# Altium schematic sheet DRL.SchDoc(DRL)
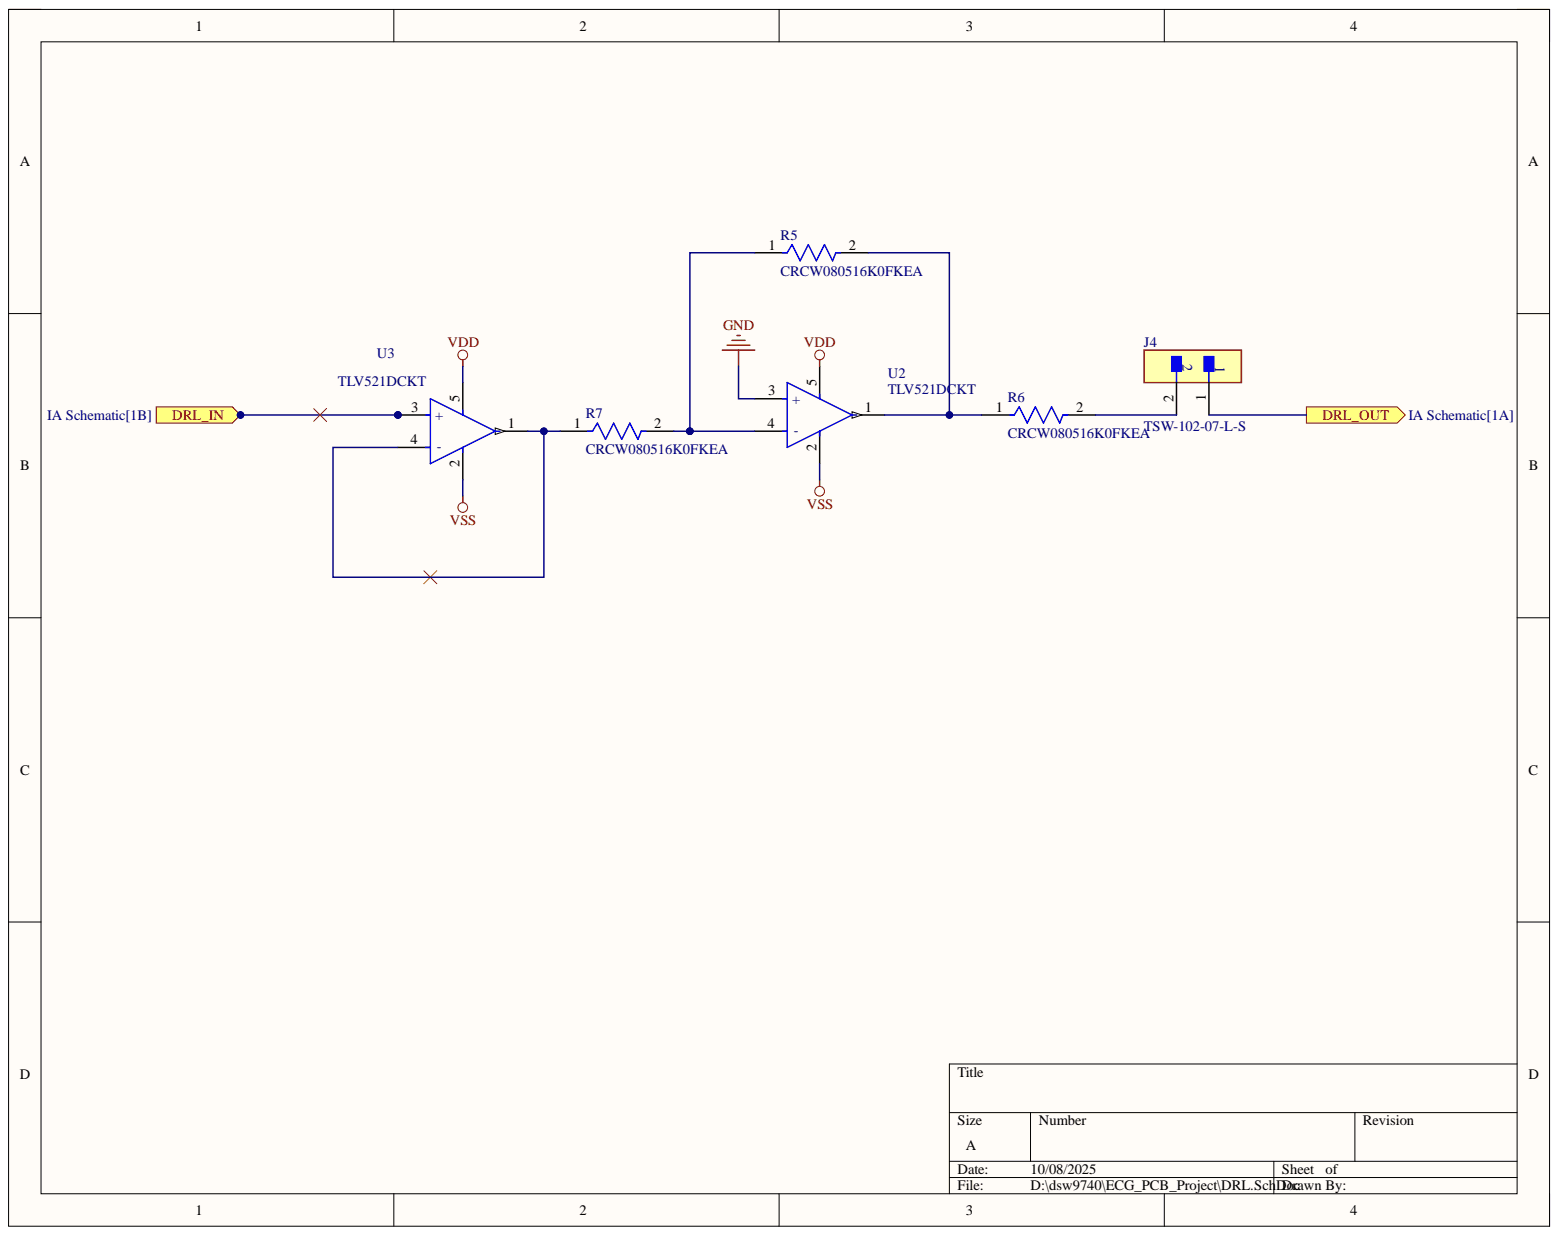
[diagram p0: DRL.SchDoc]

## Parts
J4  TSW-102-07-L-S  [FP-TSW-102-07-L-S-MFG]
R5  CRCW080516K0FKEA  [FP-CRCW0805-e3-IPC_C]
R6  CRCW080516K0FKEA  [FP-CRCW0805-e3-IPC_C]
R7  CRCW080516K0FKEA  [FP-CRCW0805-e3-IPC_C]
U2  TLV521DCKT  [FP-DCK0005A-MFG]
U3  TLV521DCKT  [FP-DCK0005A-MFG]

## Ports
DRL_IN, DRL_OUT

## Nets
GND: U2.3(+)
VDD: U2.5 U3.5
VSS: U2.2 U3.2
DRL_IN: U3.3(+) ; port DRL_IN
DRL_OUT: J4.1 ; port DRL_OUT
NetJ4_2: J4.2 R6.2
NetR5_1: R5.1 R7.2 U2.4(-)
NetR5_2: R5.2 R6.1 U2.1
NetR7_1: R7.1 U3.1 U3.4(-)

## Library data of the parts
J4 = CMP-02766-001428-1: CONN HEADER VERT 2POS 2.54MM; Connector Type: Male Pin; Current Rating: 7.6A; Gender: Male; Max Voltage Rating (AC): 550V; Number of Contacts: 2; Color: Black; Component Kind: Standard; Contact Material: Bronze; Contact Mating Length: 0.23inch; Contact Plating: Gold; Contact Type: Male Pin; Depth: 2.54mm; Housing Color: Black; Housing Material: Polyester; Lead Free: Lead Free; Length: 5.08mm; Library Name: Altium Content Vault; Max Current Rating: 7.6A; Max Operating Temperature: 125°C; Min Operating Temperature: -55°C; Mount: Through Hole; Number of Positions: 2; Number of Rows: 1; Orientation: Straight; Package Quantity: 1; Packaging: Bulk; Pitch: 2.54mm; Radiation Hardening: No; REACH SVHC: No SVHC; RoHS Compliant: Yes; Series: TSW; Termination: Solder; Voltage Rating: 550V; Width: 2.54mm
R5-R7 = CMP-2001-04979-2: RES Thick Film, 16kΩ, 1%, 0.125W, 100ppm/°C, 0805; Max Power Dissipation: 125mW; Resistance: 16kR; Voltage Rating: 150V; Case Code (Imperial): 0805; Case Code (Metric): 2012; Case/Package: 0805; Component Kind: Standard; Composition: Thick Film; Contact Plating: Tin; Depth: 1.25mm; Features: Automotive AEC-Q200; Height: 0.45mm; Lead Free: Lead Free; Length: 2mm; Library Name: Altium Content Vault; Max Operating Temperature: 155°C; Military Standard: Not; Min Operating Temperature: -55°C; Mount: Surface Mount; Number of Pins: 2; Number of Terminations: 2; Package Quantity: 10; Packaging: Tape and Reel; Power Rating: 125mW; Radiation Hardening: No; Temperature Coefficient: 100ppm/°C; Termination: SMD/SMT; Tolerance: 1%; Voltage Rating (DC): 150V; Weight: 0.000194oz; Width: 1.25mm
U2 U3 = CMP-04895-000072-1: IC CMOS 1 CIRCUIT SC70-5; Bandwidth: 6kHz; Input Bias Current: 1pA; Input Offset Voltage (Vos): 100uV; Number of Amplifiers: 1; Operating Supply Current: 350nA; Output Current per Channel: 12mA; Slew Rate: 2.5V/µs; Case/Package: SC; Component Kind: Standard; Gain Bandwidth Product: 6kHz; Lead Free: Lead Free; Library Name: Altium Content Vault; Max Operating Temperature: 125°C; Min Operating Temperature: -40°C; Mount: Surface Mount; Number of Channels: 1; Number of Circuits: 1; Number of Pins: 5; Packaging: Cut Tape; REACH SVHC: No SVHC
